# Altium schematic sheet NTC.SchDoc
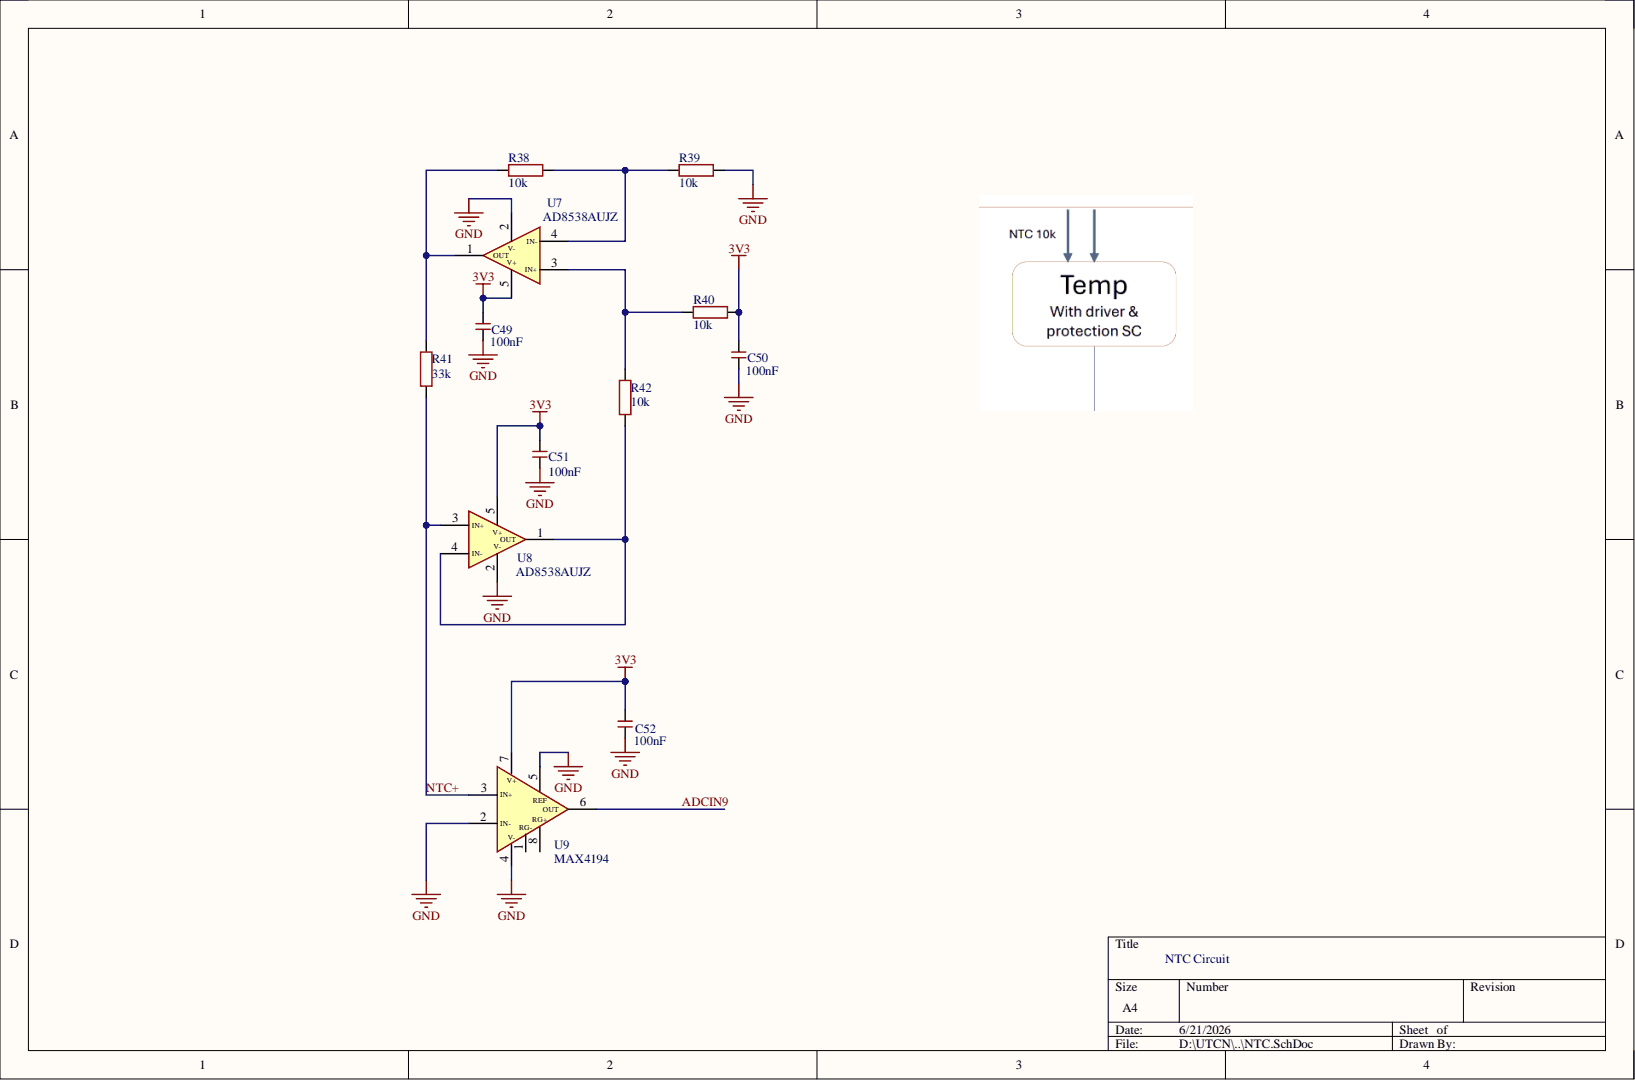
[diagram p0: NTC.SchDoc]

## Parts
C49  100nF  [C0402]
C50  100nF  [C0402]
C51  100nF  [C0402]
C52  100nF  [C0402]
R38  10k  [R0402]
R39  10k  [R0402]
R40  10k  [R0402]
R41  33k  [R0402]
R42  10k  [R0402]
U7  AD8538AUJZ  [SOT95P280X100-5N]
U8  AD8538AUJZ  [SOT95P280X100-5N]
U9  MAX4194  [SOIC127P600X175-8N]

## Nets
3V3: C49.2 C50.2 C51.2 C52.2 R40.2 U7.5(V+) U8.5(V+) U9.7(V+)
GND: C49.1 C50.1 C51.1 C52.1 R39.2 U7.2(V-) U8.2(V-) U9.2(IN-) U9.4(V-) U9.5
ADCIN9: U9.6(OUT)
NTC+: R41.1 U8.3(IN+) U9.3(IN+)
NetR38_1: R38.1 R41.2 U7.1(OUT)
NetR38_2: R38.2 R39.1 U7.4(IN-)
NetR40_1: R40.1 R42.2 U7.3(IN+)
NetR42_1: R42.1 U8.1(OUT) U8.4(IN-)

Not connected: U9 1 8

## Library data of the parts
C49-C52 = C0402C104K3PACTU: Ceramic Capacitor, Multilayer, Ceramic, 25V, 10% +Tol, 10% -Tol, X5R, 15% TC, 0.1uF, Surface Mount, 0402; Manufacturer: KEMET; Multilayer: Yes; Capacitor Type: Ceramic; Case/Package: 0402; Category: Ceramic Capacitors; Component Kind: Standard; ComponentLink1Description: https://datasheet.ciiva.com:443/pdfs/VipMasterIC/IC/KEME/KEME-S-A0008991665/KEME-S-A0008991665-1.pdf?src-supplier=IHS+Markit; ComponentLink1URL: https://datasheet.ciiva.com:443/pdfs/VipMasterIC/IC/KEME/KEME-S-A0008991665/KEME-S-A0008991665-1.pdf?src-supplier=IHS+Markit; ComponentLink2Description: http://www.farnell.com/datasheets/2748284.pdf?src-supplier=Farnell; ComponentLink2URL: http://www.farnell.com/datasheets/2748284.pdf?src-supplier=Farnell; ComponentLink3Description: http://www.farnell.com/datasheets/2748284.pdf?src-supplier=Newark; ComponentLink3URL: http://www.farnell.com/datasheets/2748284.pdf?src-supplier=Newark; ComponentLink4Description: https://datasheet.octopart.com/C0402C104K3PACTU-KEMET-datasheet-181956028.pdf?src-supplier=Element14; ComponentLink4URL: https://datasheet.octopart.com/C0402C104K3PACTU-KEMET-datasheet-181956028.pdf?src-supplier=Element14; Dielectric Material: Ceramic; JESD-609 Code: e3; Library Name: Schlib1.SchLib; Manufacturer Part Number: C0402C104K3PACTU; Max Operating Temperature: 85°C; Min Operating Temperature: -55°C; Mounting Technology: Surface Mount; Package Shape: Rectangular; Packaging: Tape and Reel; Pins: 2; Pricing: 1=0.12, 10=0.056, 50=0.056, 100=0.036, 1000=0.026, 10000=0.017 (USD); Rated DC Voltage (URdc): 25V; Reach SVHC Compliant: Yes; RoHS: Yes; RoHS Compliant: Yes; Stock: 262570; Supplier: Mouser; Supplier Part Number: 80-C0402C104K3P; Temperature Characteristics Code: X5R; Terminal Finish: Nickel; Termination: Wraparound; Tolerance  (fill in as +-): 10%; Tolerance (fill in as +-): 10%; Value: 100nF
R38-R40 R42 = RP0402BRD0710KL: Resistor, 10K, 0.1%, 0.063W, THIN FILM, 0402;; Manufacturer: Yageo; Category: Chip SMD Resistors; Component Kind: Standard; ComponentLink1Description: https://datasheet.octopart.com/RP0402BRD0710KL-Yageo-datasheet-179178423.pdf?src-supplier=Newark; ComponentLink1URL: https://datasheet.octopart.com/RP0402BRD0710KL-Yageo-datasheet-179178423.pdf?src-supplier=Newark; Library Name: Schlib1.SchLib; Manufacturer Part Number: RP0402BRD0710KL; Pricing: 1=0.24, 10=0.171, 50=0.134, 100=0.12, 1000=0.092, 10000=0.074 (USD); RoHS: No; Stock: 21771; Supplier: Mouser; Supplier Part Number: 603-RP0402BRD0710KL
R41 = RC0402FR-7D33KL: Fixed Resistor, Metal Glaze/thick Film, 0.0625W, 33000ohm, 50V, 1% +/-Tol, 100ppm/Cel, Surface Mount, 0402; Manufacturer: Yageo; Category: Resistors; Component Kind: Standard; Library Name: Schlib1.SchLib; Manufacturer Part Number: RC0402FR-7D33KL; Pricing: 1=0.1, 10=0.01, 50=0.01, 100=0.007, 1000=0.006, 10000=0.003 (USD); RoHS: Yes; Stock: 38172; Supplier: Mouser; Supplier Part Number: 603-RC0402FR-7D33KL
U7 U8 = AD8538AUJZ-REEL7: Operational Amplifier, 1 Func, 30uV Offset-Max, CMOS, PDSO5; Manufacturer: Analog Devices; Architecture: VOLTAGE-FEEDBACK; Average Bias Current-Max (IIB): 100pA; Bias Current-Max (IIB) @25C: 25pA; Channels: 1; Common-mode Reject Ratio-Nom: 135dB; Frequency Compensation: YES; Max Supply Current: 215uA; Neg Supply Voltage Limit-Max: 0V; Nominal Supply Voltage: 5V; Package Body Material: Plastic; Package Equivalence Code: TSOP5/6,.11,37; Power: No; Power Supplies: +-1.35/+-2.5/2.7/5V; Qualification Status: Not Qualified; Slew Rate: 0.4V/µs; Supply Voltage Limit-Max: 6V; Time @ Peak Reflow Temperature-Max (s): 40s; Unity Gain BW-Nom: 430kHz; Voltage Gain-Min: 178000; Amplifier Type: OPERATIONAL AMPLIFIER; Case/Package: VSSOP; Category: Amplifiers - Op Amps, Buffer, Instrumentation; Component Kind: Standard; ComponentLink1Description: https://datasheet.ciiva.com:443/pdfs/VipMasterIC/IC/ANDI/ANDIS13894/ANDIS13894-1.pdf?src-supplier=IHS+Markit; ComponentLink1URL: https://datasheet.ciiva.com:443/pdfs/VipMasterIC/IC/ANDI/ANDIS13894/ANDIS13894-1.pdf?src-supplier=IHS+Markit; ComponentLink2Description: https://datasheet.ciiva.com/15374/ad8538-8539-243268-15374656.pdf?src-supplier=Mouser; ComponentLink2URL: https://datasheet.ciiva.com/15374/ad8538-8539-243268-15374656.pdf?src-supplier=Mouser; ComponentLink3Description: https://datasheet.ciiva.com/2974/ad8538-8539-2974672.pdf?src-supplier=Avnet; ComponentLink3URL: https://datasheet.ciiva.com/2974/ad8538-8539-2974672.pdf?src-supplier=Avnet; ComponentLink4Description: https://datasheet.ciiva.com/1793/ad8538-8539-1793940.pdf?src-supplier=Digikey; ComponentLink4URL: https://datasheet.ciiva.com/1793/ad8538-8539-1793940.pdf?src-supplier=Digikey; ComponentLink5Description: https://datasheet.ciiva.com/1793/ad8538-8539-1793941.pdf?src-supplier=Digikey; ComponentLink5URL: https://datasheet.ciiva.com/1793/ad8538-8539-1793941.pdf?src-supplier=Digikey; ComponentLink6Description: https://datasheet.ciiva.com/1793/ad8538-8539-1793942.pdf?src-supplier=Digikey; ComponentLink6URL: https://datasheet.ciiva.com/1793/ad8538-8539-1793942.pdf?src-supplier=Digikey; ComponentLink7Description: https://datasheet.ciiva.com/2973/ad8538-8539-2973062.pdf?src-supplier=Avnet; ComponentLink7URL: https://datasheet.ciiva.com/2973/ad8538-8539-2973062.pdf?src-supplier=Avnet; Height - Seated (Max): 1mm; Input Offset Voltage-Max: 30uV; JESD-30 Code: R-PDSO-G5; JESD-609 Code: e3; Length: 2.9mm; Library Name: Schlib1.SchLib; Low-Bias: Yes; Low-Offset: Yes; Manufacturer Part Number: AD8538AUJZ-REEL7; Max Operating Temperature: 125°C; Micropower: Yes; Min Operating Temperature: -40°C; Moisture Sensitivity Level: 1; Neg Supply Voltage-Nom (Vsup): 0V; Package Shape: Rectangular; Package Style: SMALL OUTLINE, VERY THIN PROFILE, SHRINK PITCHMeter; Packaging: Tape and Reel; Peak Reflow Temperature (Cel): 260°C; Pins: 5; Pricing: 1=1.65, 10=1.42, 50=1.42, 100=1.25, 1000=0.916, 10000=0.84 (USD); Programmable Power: No; Reach SVHC Compliant: Yes; RoHS: Yes; RoHS Compliant: Yes; Stock: 130448; Supplier: Mouser; Supplier Part Number: 584-AD8538AUJZ-R7; Surface Mount: Yes; Technology: CMOS; Temperature Grade: AUTOMOTIVE; Terminal Finish: Tin; Terminal Form: Gull Wing; Terminal Pitch: 0.95mm; Terminal Position: DUAL; Wideband: No; Width: 1.6mm
U9 = MAX4194ESA+: Instrumentation Amplifier, 1 Func, 690uV Offset-Max, 0.25MHz Band Width, PDSO8; Manufacturer: Maxim; Average Bias Current-Max (IIB): 20nA; Bandwidth (3dB)-Nom: 250kHz; Channels: 1; Common Mode Rejection Ratio-Min: 73dB; Input Offset Current-Max (IIO): 3nA; Max Supply Current: 110uA; Nominal Supply Voltage: 5V; Package Body Material: Plastic; Package Equivalence Code: SOP8,.25; Power Supplies: +-1.35/+-3.75/2.7/7.5V; Qualification Status: Not Qualified; Slew Rate: 0.06V/µs; Supply Voltage Limit-Max: 8V; Time @ Peak Reflow Temperature-Max (s): 30s; Voltage Gain-Max: 10000; Amplifier Type: INSTRUMENTATION AMPLIFIER; Case/Package: SOP; Category: Amplifiers - Op Amps, Buffer, Instrumentation; Component Kind: Standard; ComponentLink1Description: https://datasheet.ciiva.com:443/pdfs/VipMasterIC/IC/MAXM/MAXMS15090/MAXMS15090-1.pdf?src-supplier=IHS+Markit; ComponentLink1URL: https://datasheet.ciiva.com:443/pdfs/VipMasterIC/IC/MAXM/MAXMS15090/MAXMS15090-1.pdf?src-supplier=IHS+Markit; ComponentLink2Description: https://datasheet.ciiva.com/2975/max4194-max4197-2975858.pdf?src-supplier=Avnet; ComponentLink2URL: https://datasheet.ciiva.com/2975/max4194-max4197-2975858.pdf?src-supplier=Avnet; ComponentLink3Description: https://datasheet.ciiva.com/14338/max4194-max4197-14338475.pdf?src-supplier=Avnet; ComponentLink3URL: https://datasheet.ciiva.com/14338/max4194-max4197-14338475.pdf?src-supplier=Avnet; ComponentLink4Description: https://datasheet.ciiva.com/1802/max4194-max4197-1802609.pdf?src-supplier=Digikey; ComponentLink4URL: https://datasheet.ciiva.com/1802/max4194-max4197-1802609.pdf?src-supplier=Digikey; ComponentLink5Description: https://datasheet.ciiva.com/1819/max4194-max4197-1819822.pdf?src-supplier=Digikey; ComponentLink5URL: https://datasheet.ciiva.com/1819/max4194-max4197-1819822.pdf?src-supplier=Digikey; Height - Seated (Max): 1.75mm; Input Offset Voltage-Max: 690uV; JESD-30 Code: R-PDSO-G8; JESD-609 Code: e3; Length: 4.9mm; Library Name: Schlib1.SchLib; Manufacturer Part Number: MAX4194ESA+; Max Operating Temperature: 85°C; Min Operating Temperature: -40°C; Moisture Sensitivity Level: 1; Package Shape: Rectangular; Package Style: SMALL OUTLINEMeter; Peak Reflow Temperature (Cel): 260°C; Pins: 8; Pricing: 1=7.06, 10=5.31, 50=5.17, 100=4.65, 1000=3.83, 10000=3.83 (USD); Reach SVHC Compliant: Yes; RoHS: Yes; RoHS Compliant: Yes; Stock: 2146; Supplier: Mouser; Supplier Part Number: 700-MAX4194ESA; Surface Mount: Yes; Temperature Grade: INDUSTRIAL; Terminal Finish: Tin; Terminal Form: Gull Wing; Terminal Pitch: 1.27mm; Terminal Position: DUAL; Voltage Gain-Min: 1; Width: 3.9mm
